# Altium schematic sheet ETR_CELLMETER_SLAVE.SchDoc
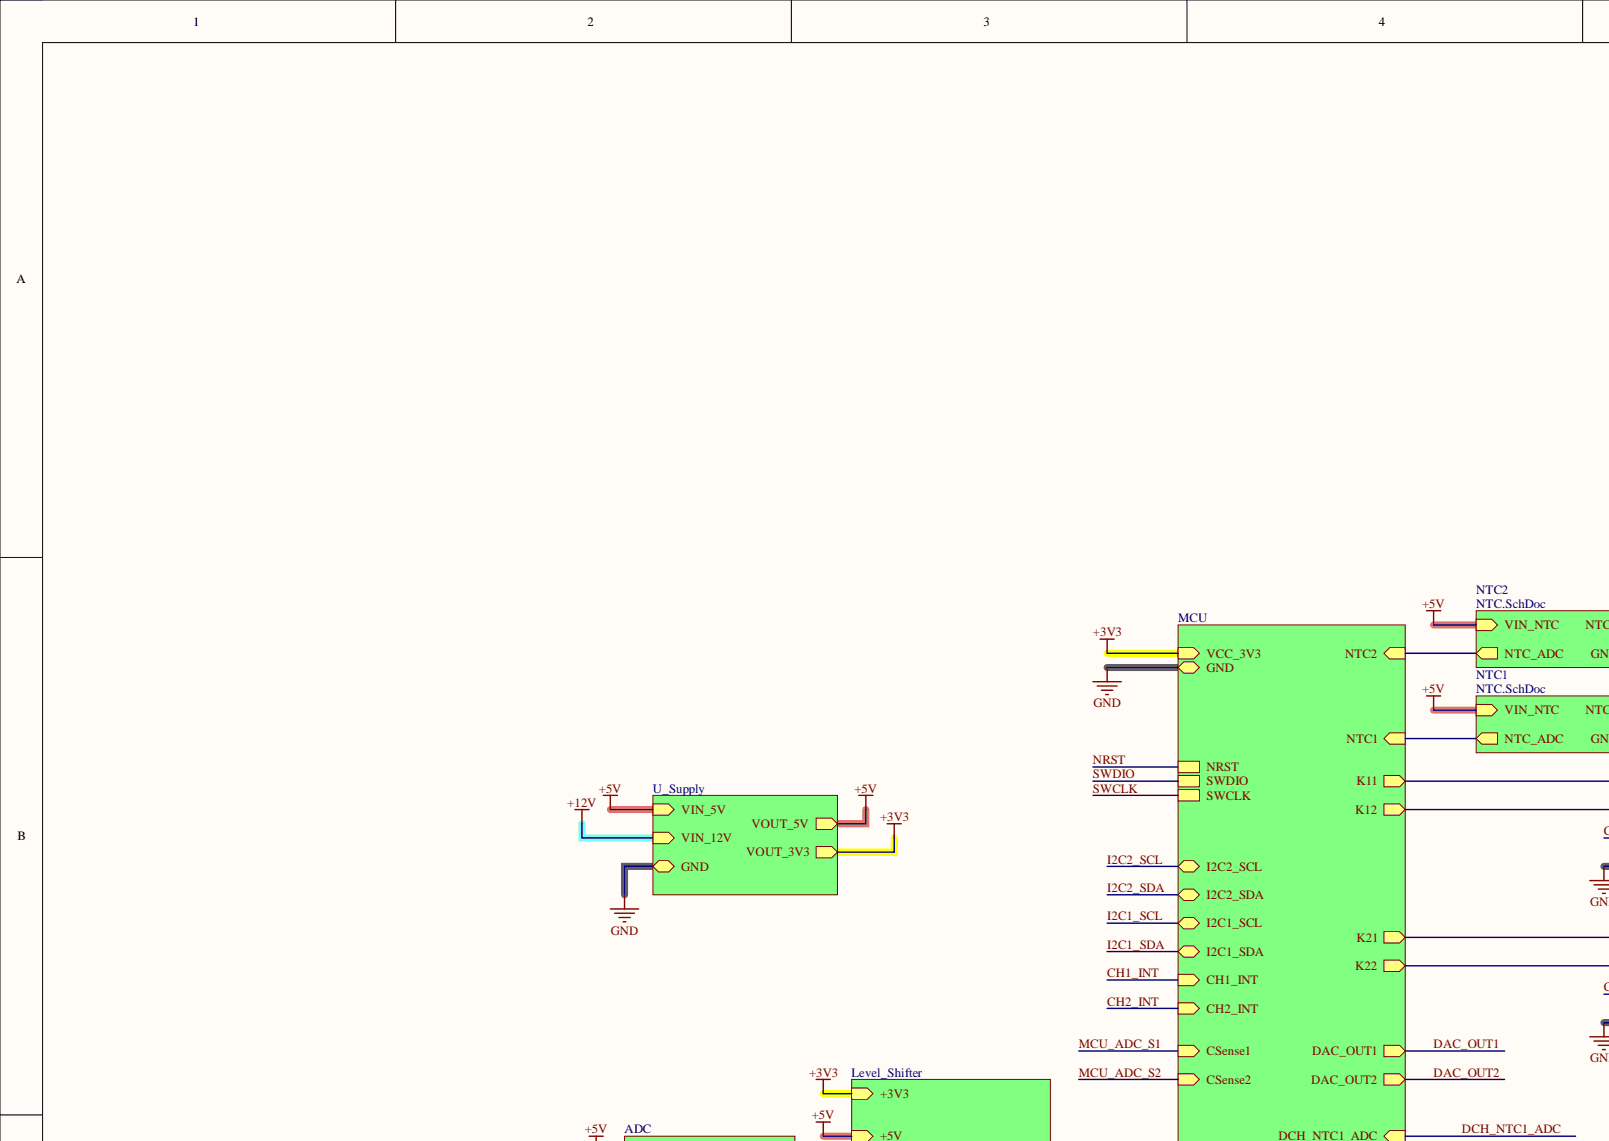
[diagram p0: ETR_CELLMETER_SLAVE.SchDoc, top left]
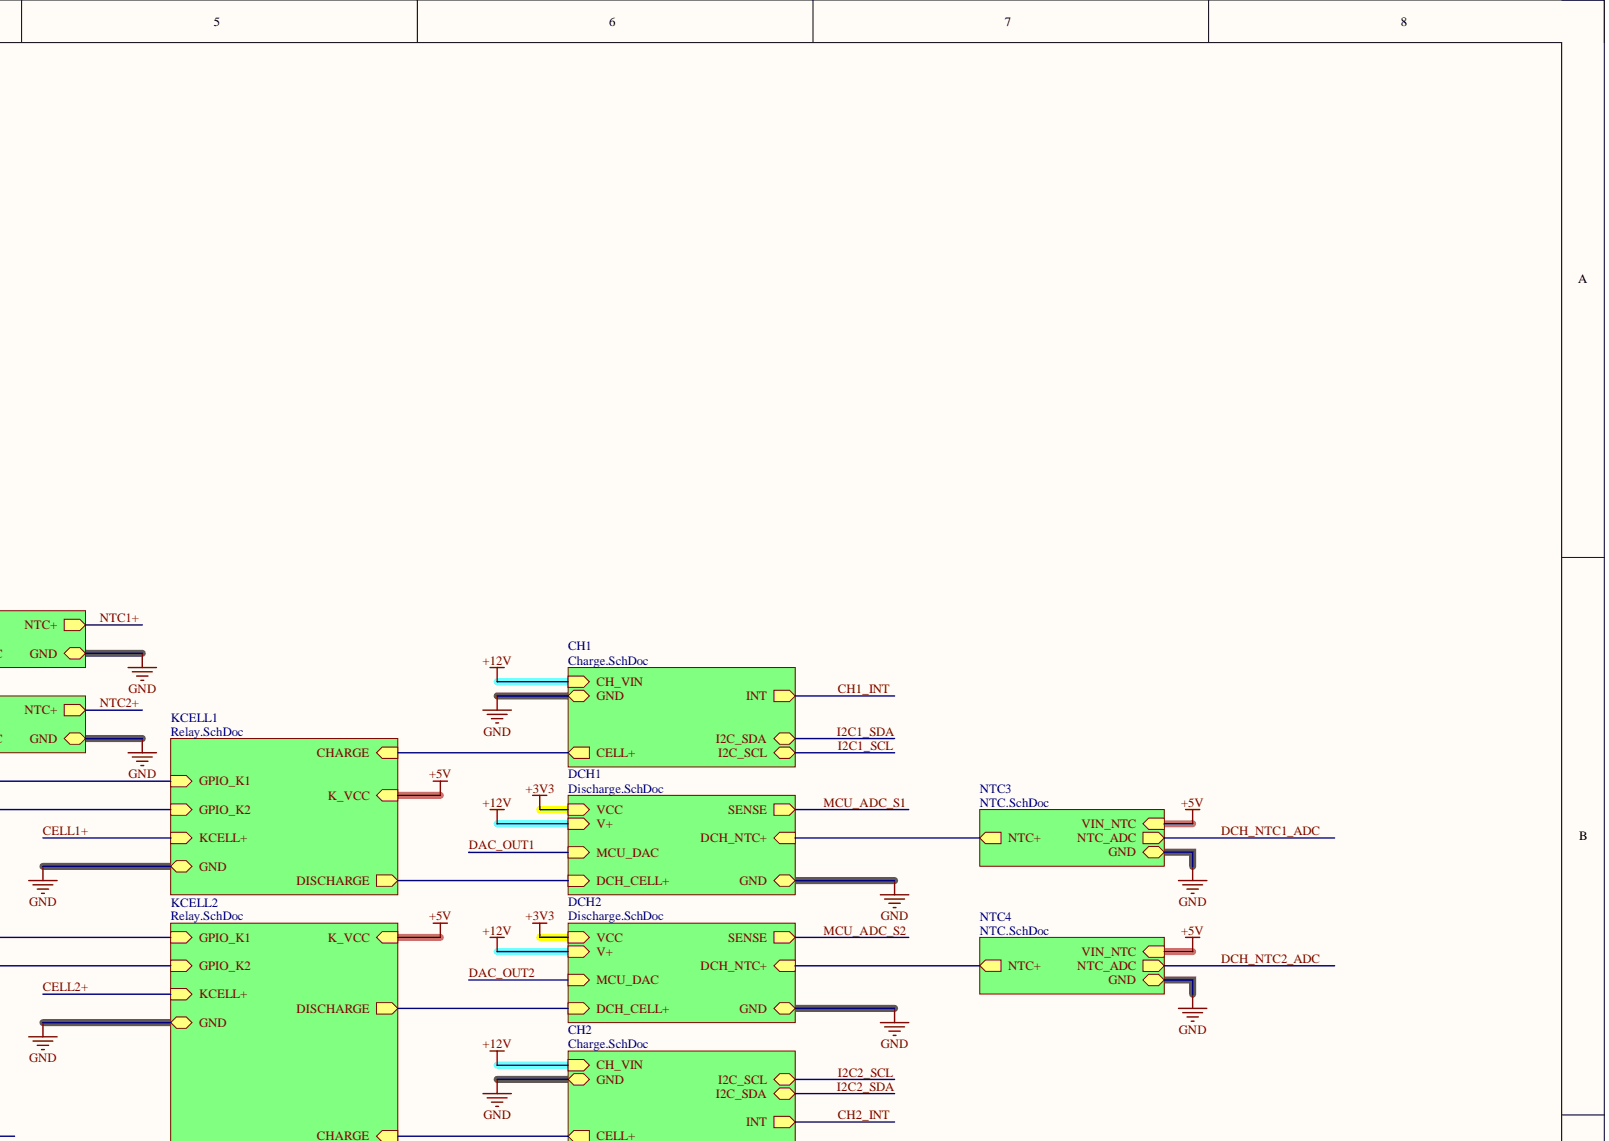
[diagram p1: ETR_CELLMETER_SLAVE.SchDoc, top right]
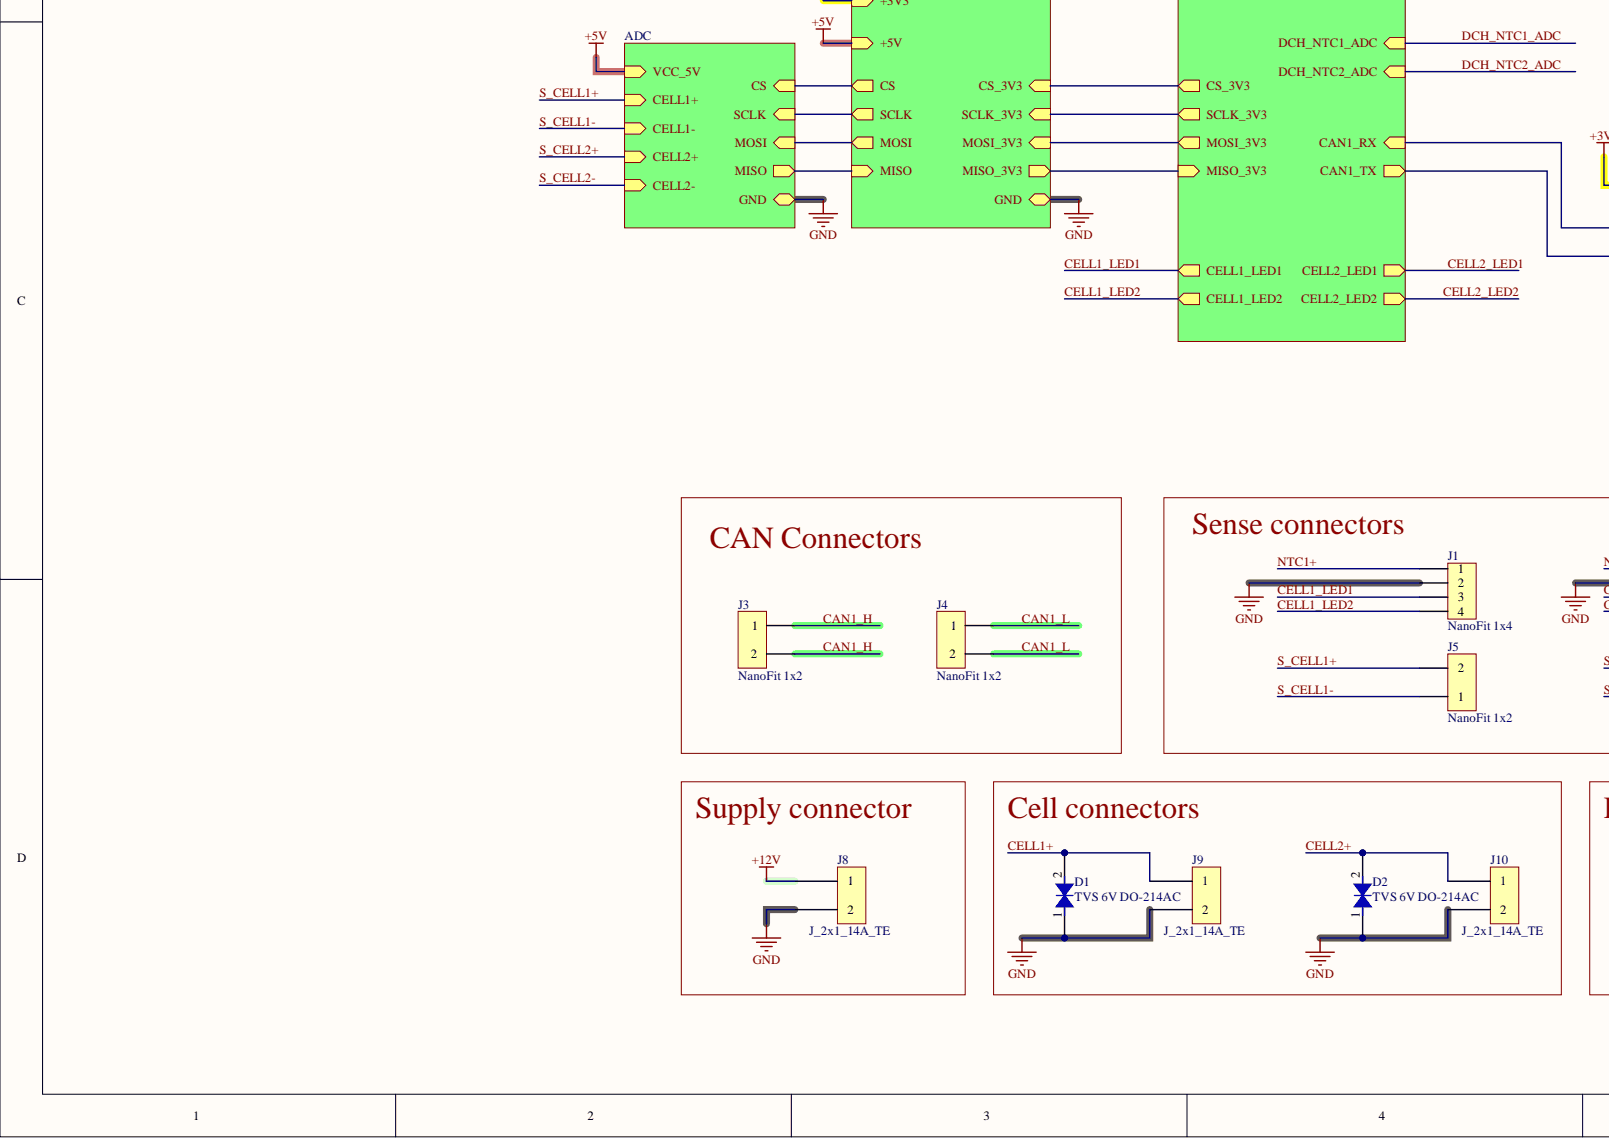
[diagram p2: ETR_CELLMETER_SLAVE.SchDoc, bottom left]
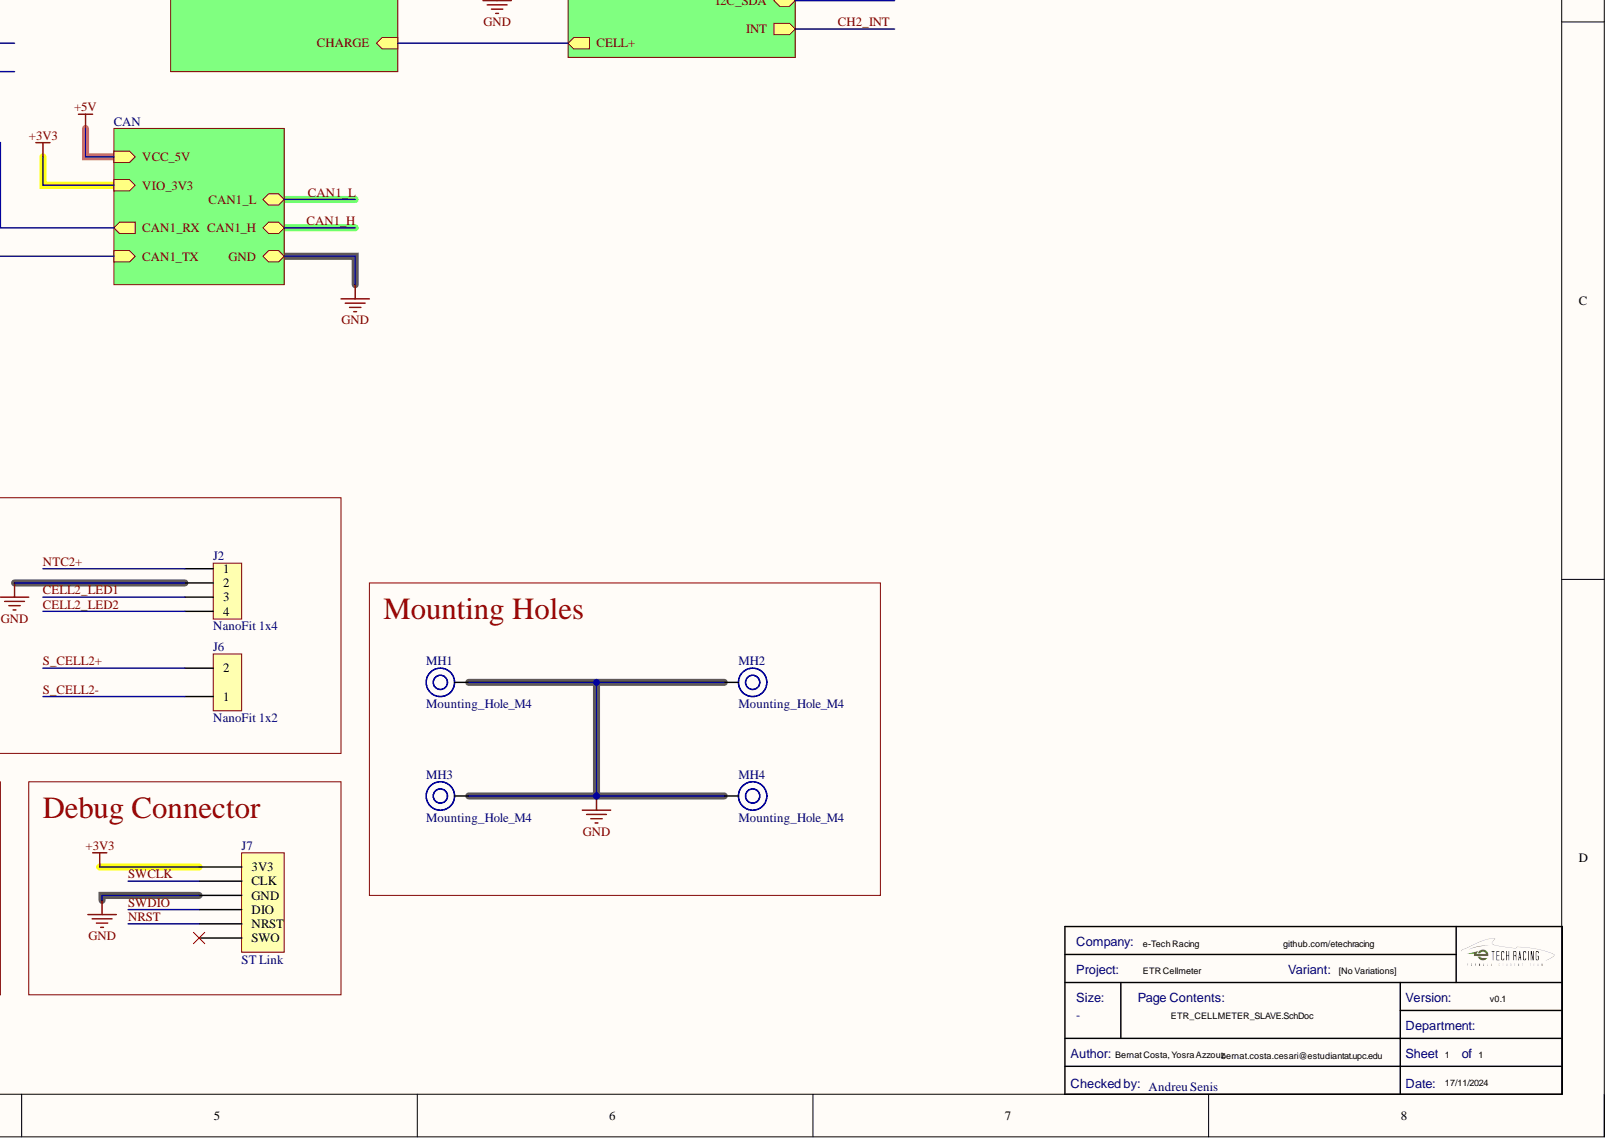
[diagram p3: ETR_CELLMETER_SLAVE.SchDoc, bottom right]

## Parts
D1  TVS 6V DO-214AC  Reverse Standoff Voltage: 6V  [DTVS-DO-214AC]
D2  TVS 6V DO-214AC  Reverse Standoff Voltage: 6V  [DTVS-DO-214AC]
J1  NanoFit 1x4  [J_NanoFit_1x4_V]
J2  NanoFit 1x4  [J_NanoFit_1x4_V]
J3  NanoFit 1x2  [FP-105309-1102-MFG]
J4  NanoFit 1x2  [FP-105309-1102-MFG]
J5  NanoFit 1x2  [FP-105309-1102-MFG]
J6  NanoFit 1x2  [FP-105309-1102-MFG]
J7  ST Link  [J_STLink_PinHeader]
J8  J_2x1_14A_TE  [179838-2]
J9  J_2x1_14A_TE  [179838-2]
J10  J_2x1_14A_TE  [179838-2]
MH1  Mounting_Hole_M4  [Mounting_Hole_M4]
MH2  Mounting_Hole_M4  [Mounting_Hole_M4]
MH3  Mounting_Hole_M4  [Mounting_Hole_M4]
MH4  Mounting_Hole_M4  [Mounting_Hole_M4]

## Nets
+3V3: J7.1(3V3)
+12V: J8.1
GND: D1.1 D2.1 J1.2 J2.2 J7.3(GND) J8.2 J9.2 J10.2 MH1.1 MH2.1 MH3.1 MH4.1
CAN1_H: J3.1 J3.2
CAN1_L: J4.1 J4.2
CELL1+: D1.2 J9.1
CELL1_LED1: J1.3
CELL1_LED2: J1.4
CELL2+: D2.2 J10.1
CELL2_LED1: J2.3
CELL2_LED2: J2.4
NRST: J7.5(NRST)
NTC1+: J1.1
NTC2+: J2.1
S_CELL1+: J5.2
S_CELL1-: J5.1
S_CELL2+: J6.2
S_CELL2-: J6.1
SWCLK: J7.2(CLK)
SWDIO: J7.4(DIO)
NetJ7_6: J7.6(SWO)
+5V:
CAN1_RX:
CAN1_TX:
CELL+:
CH1_INT:
CH2_INT:
CS:
CS_3V3:
DAC_OUT1:
DAC_OUT2:
DCH_CELL+:
DCH_NTC1_ADC:
DCH_NTC2_ADC:
DCH_NTC+:
GPIO_K1:
GPIO_K2:
I2C1_SCL:
I2C1_SDA:
I2C2_SCL:
I2C2_SDA:
MCU_ADC_S1:
MCU_ADC_S2:
MISO:
MISO_3V3:
MOSI:
MOSI_3V3:
NTC1:
NTC2:
SCLK:
SCLK_3V3:

## Library data of the parts
D1 D2 = CMP-00154-1: TVS diode [Würth Elektronik] 824501600 TVS diode; Manufacturer: Wurth Electronics; .Creator: DO; Clamping Voltage: 10.3V; Forward Voltage: 7.02V; Number of Bidirectional Channels: 1; Peak Pulse Current: 38.8A; Peak Pulse Power: 400W; Polarity: Bidirectional; Power Line Protection: No; Category: TVS Diodes; Component Kind: Standard; ComponentLink1Description: Where buy it; ComponentLink1URL: https://www.we-online.com/catalog/en/WE-TVSP?sq=824501600#824501600; ComponentLink2Description: http://www.farnell.com/datasheets/2036401.pdf?src-supplier=Element14; ComponentLink2URL: http://www.farnell.com/datasheets/2036401.pdf?src-supplier=Element14; ComponentLink3Description: https://katalog.we-online.de/pbs/datasheet/824501600.pdf?src-supplier=Digi-Key; ComponentLink3URL: https://katalog.we-online.de/pbs/datasheet/824501600.pdf?src-supplier=Digi-Key; Design Item ID: DTVS-6V-DO-214AC; Manufacturer Part Number: 824501600; Max Operating Temperature: 150°C; Min Breakdown Voltage: 7.02V; Min Operating Temperature: -65°C; Mount: Surface Mount; Packaging: Cut Tape; Pins: 2; Pricing 1: 1=0,28000, 10=0,26100, 50=0,26100, 100=0,23400, 500=0,20400, 1000=0,17400, 10000=0,17400 (USD); REACH SVHC: Unknown; Resistor Type: Zener; RoHS: Yes; RoHS Compliant: Yes; Source: Filter components.SchLib; Stock 1: 780; Library Name: EMEA- E-Tech Racing- I'Escola d'Enginyeria de Barcelona Est
J1 J2 = CMP-00018-1: Nano-Fit Vertical Header Through Hole 2.50mm Pitch Single Row 4 Circuits with Kinked Pins 0.38; Manufacturer 1: Molex; Manufacturer Part Number 1: 105309-1204; .Creator: DO; Category: Headers and Wire Housings; Component Kind: Standard; ComponentLink1Description: http://farnell.com//datasheets/2221349.pdf?src-supplier=Farnell; ComponentLink1URL: http://farnell.com//datasheets/2221349.pdf?src-supplier=Farnell; ComponentLink2Description: http://www.farnell.com/datasheets/2221349.pdf?src-supplier=Newark; ComponentLink2URL: http://www.farnell.com/datasheets/2221349.pdf?src-supplier=Newark; ComponentLink3Description: http://www.literature.molex.com/SQLImages/kelmscott/Molex/PDF_Images/987651-1223.PDF?src-supplier=Digi-Key; ComponentLink3URL: http://www.literature.molex.com/SQLImages/kelmscott/Molex/PDF_Images/987651-1223.PDF?src-supplier=Digi-Key; ComponentLink4Description: http://www.farnell.com/datasheets/2059602.pdf?src-supplier=Element14; ComponentLink4URL: http://www.farnell.com/datasheets/2059602.pdf?src-supplier=Element14; Design Item ID: J_NanoFit_1x4_V; Pricing 1: 1=1,37050, 10=1,11700, 100=0,95270, 500=0,86980, 1000=0,74060, 2500=0,71930, 5000=0,68450, 10000=0,66860 (USD); RoHS: No; Source: Electromechanical.SchLib; Stock 1: 709; Library Name: EMEA- E-Tech Racing- I'Escola d'Enginyeria de Barcelona Est
J3-J6 = CMP-002-00001-2: Conn HDR 2 POS 2.5mm Solder ST Thru-Hole 2 Terminal 1 Port Nano-Fit Tray; Component Kind: Standard; Library Name: EMEA- E-Tech Racing- I'Escola d'Enginyeria de Barcelona Est
J7 = J_STLink_STM32_PinHeader_1x6: Component Kind: Standard; Library Name: Electromechanical.SchLib
J8-J10 = CMP-002-00003-2: 179838-2; Connector Type: Power,Rectangular; Component Kind: Standard; Design Item ID: Power 2 pin connector; Max Operating Temperature: 105°C; Min Operating Temperature: -30°C; Mounting Technology: Board; RoHS Compliant: Yes; Source: Electromechanical.SchLib; Library Name: EMEA- E-Tech Racing- I'Escola d'Enginyeria de Barcelona Est
MH1-MH4 = CMP-00041-8: Mounting Hole M4; Component Kind: Standard; Design Item ID: Mounting_Hole_M4; Source: Electromechanical.SchLib; Library Name: EMEA- E-Tech Racing- I'Escola d'Enginyeria de Barcelona Est
